# Altium schematic sheet Power.SchDoc(Power)
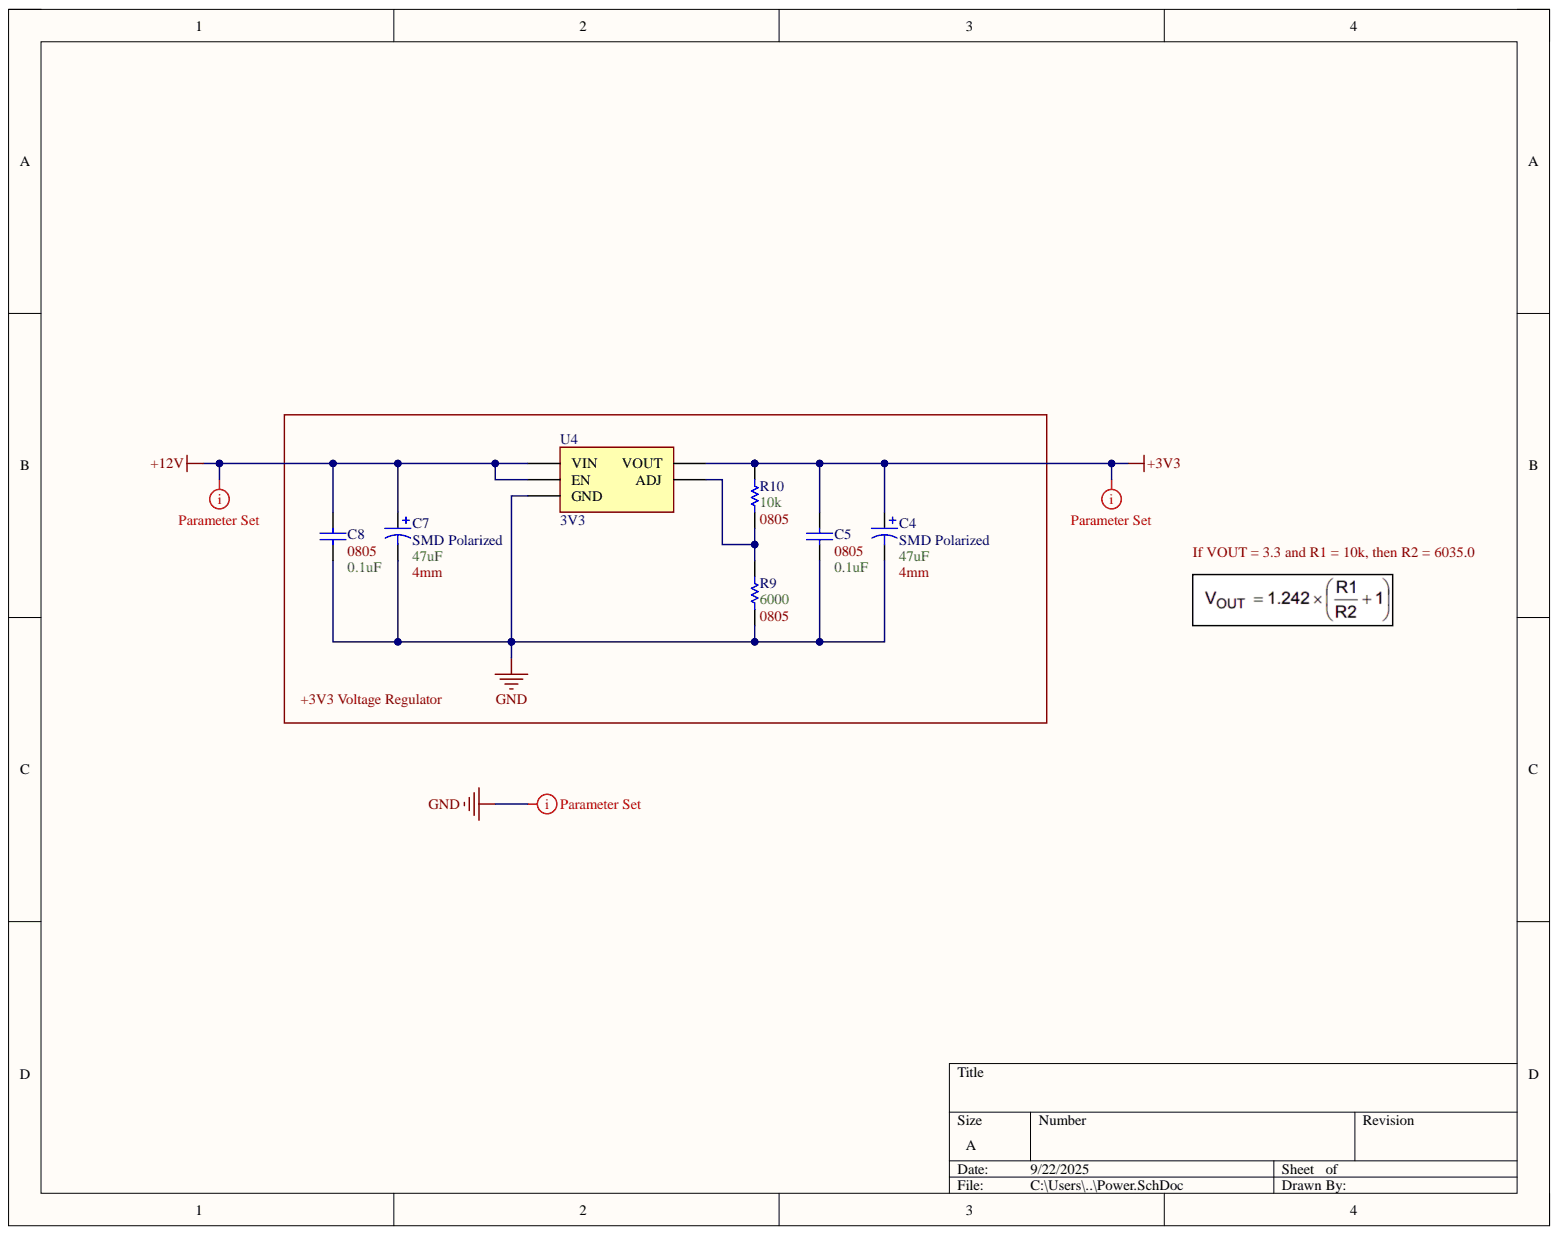
[diagram p0: Power.SchDoc]

## Parts
C4  SMD Polarized  Capacitance: 47uF  Diameter: 4mm  [[Cap] SMD Polarized, 4mm]
C5  Capacitance: 0.1uF  Size Package: 0805  [[Cap] SMD 0805]  (hidden comment: SMD Nonpolarized)
C7  SMD Polarized  Capacitance: 47uF  Diameter: 4mm  [[Cap] SMD Polarized, 4mm]
C8  Capacitance: 0.1uF  Size Package: 0805  [[Cap] SMD 0805]  (hidden comment: SMD Nonpolarized)
R9  Resistance: 6000  Size Package: 0805  [[Res] SMD 0805]  (hidden comment: [Res] SMD Resistor)
R10  Resistance: 10k  Size Package: 0805  [[Res] SMD 0805]  (hidden comment: [Res] SMD Resistor)
U4  3V3  [[Reg] Variable Voltage Regulator]

## Nets
+3V3: C4.1 C5.2 R10.2 U4.4(VOUT)
+12V: C7.1 C8.2 U4.1(EN) U4.2(VIN)
GND: C4.2 C5.1 C7.2 C8.1 R9.1 U4.3(GND)
NetR9_2: R9.2 R10.1 U4.5(ADJ)

## Library data of the parts
C4 C7 = [Cap] SMD Polarized: Component Kind: Standard; Library Name: RADM Components.IntLib
C5 C8 = [Cap] SMD Nonpolarized: Component Kind: Standard; Library Name: RADM Components.IntLib
U4 = [Reg] Variable Voltage Regulator: MIC29302WT; Component Kind: Standard; Library Name: RADM Components.IntLib
R9 R10 = [Res] SMD Resistor: Component Kind: Standard; Library Name: RADM Components.IntLib
